# Altium schematic sheet FPGA_IO.SchDoc
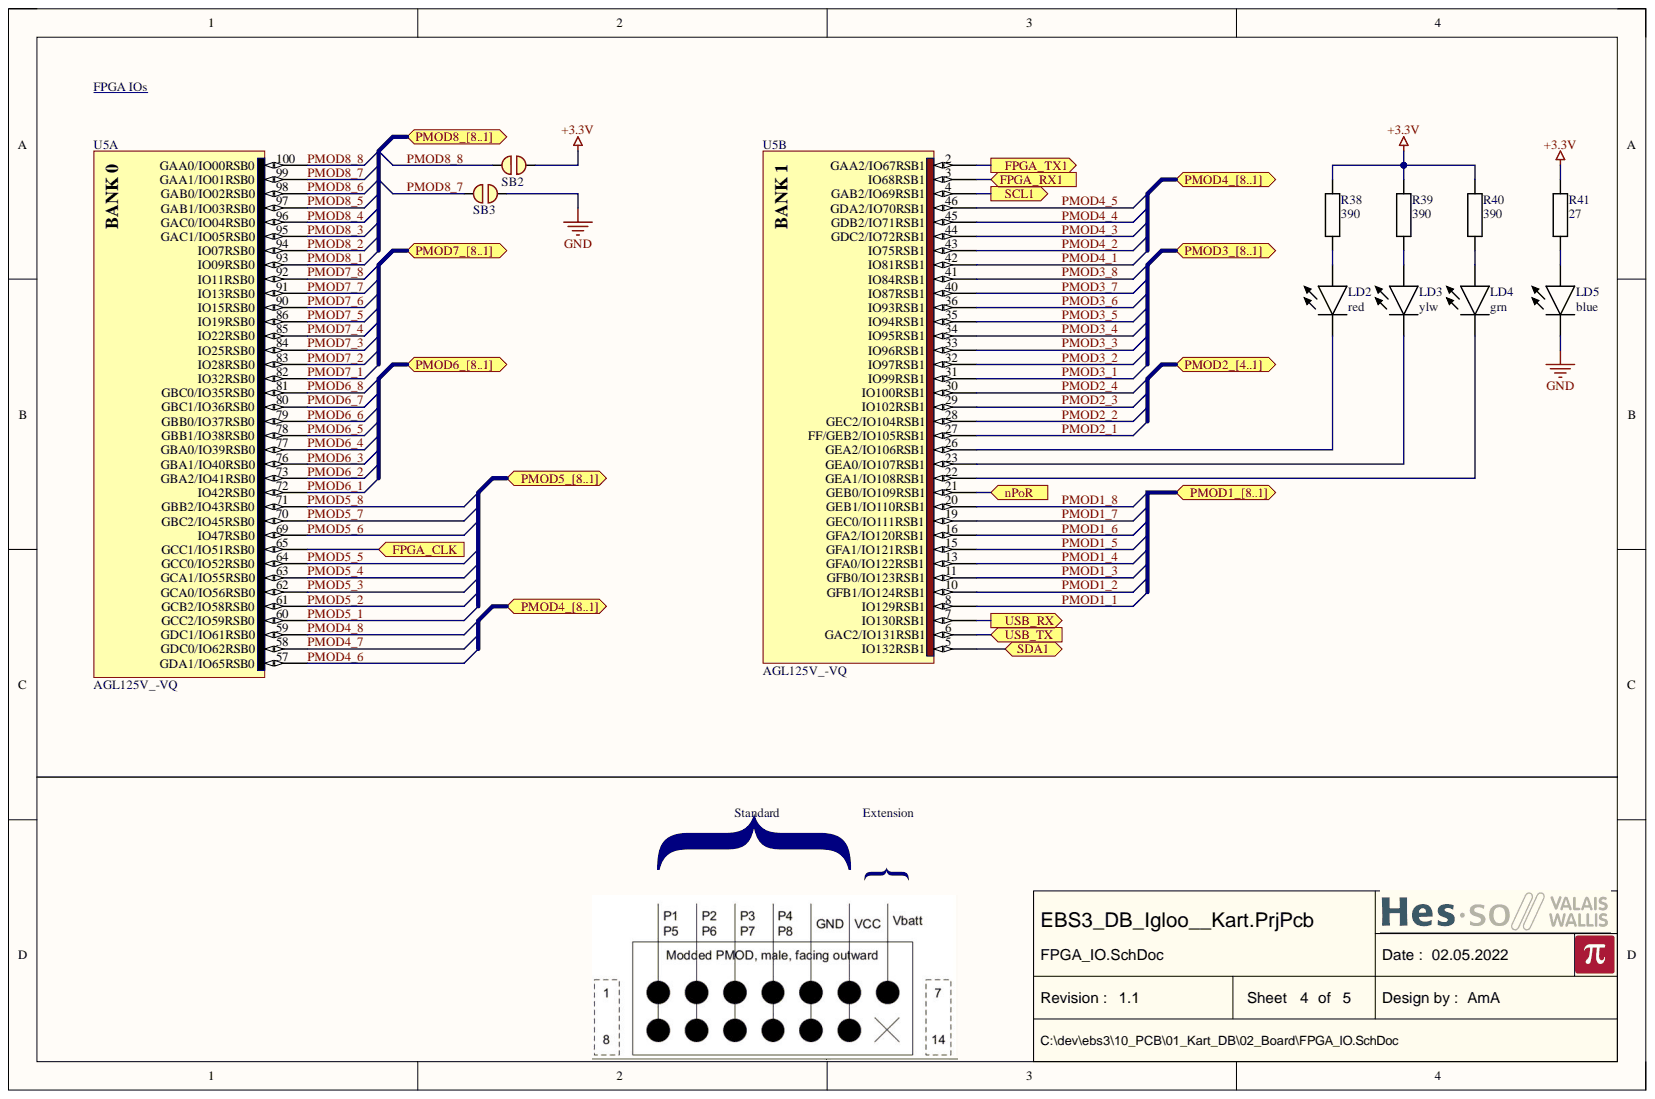
[diagram p0: FPGA_IO.SchDoc]

## Parts
LD2  red  [LED 1608 REFLOW]
LD3  ylw  [LED 1608 REFLOW]
LD4  grn  [LED 1608 REFLOW]
LD5  blue  [LED 1608 REFLOW]
R38  390  [RESISTOR 1608 REFLOW]
R39  390  [RESISTOR 1608 REFLOW]
R40  390  [RESISTOR 1608 REFLOW]
R41  27  [RESISTOR 1608 REFLOW]
SB2  (no value)  [Solder Bridge 1mm Unconnected]
SB3  (no value)  [Solder Bridge 1mm Unconnected]
U5  AGL125V_-VQ  [VQ100 P0.5 C14x14 H1.2]  (parts A,B)

## Ports
FPGA_CLK, FPGA_RX1, FPGA_TX1, nPoR, PMOD1_1, PMOD1_2, PMOD1_3, PMOD1_4, PMOD1_5, PMOD1_6, PMOD1_7, PMOD1_8, PMOD1_[8..1], PMOD2_1, PMOD2_2, PMOD2_3, PMOD2_4, PMOD2_[4..1], PMOD3_1, PMOD3_2, PMOD3_3, PMOD3_4, PMOD3_5, PMOD3_6, PMOD3_7, PMOD3_8, PMOD3_[8..1], PMOD4_1, PMOD4_2, PMOD4_3, PMOD4_4, PMOD4_5, PMOD4_6, PMOD4_7, PMOD4_8, PMOD4_[8..1], PMOD5_1, PMOD5_2, PMOD5_3, PMOD5_4, PMOD5_5, PMOD5_6, PMOD5_7, PMOD5_8, PMOD5_[8..1], PMOD6_1, PMOD6_2, PMOD6_3, PMOD6_4, PMOD6_5, PMOD6_6, PMOD6_7, PMOD6_8, PMOD6_[8..1], PMOD7_1, PMOD7_2, PMOD7_3, PMOD7_4, PMOD7_5, PMOD7_6, PMOD7_7, PMOD7_8, PMOD7_[8..1], PMOD8_1, PMOD8_2, PMOD8_3, PMOD8_4, PMOD8_5, PMOD8_6, PMOD8_7, PMOD8_8, PMOD8_[8..1], SCL1, SDA1, USB_RX, USB_TX

## Nets
+3.3V: R38.2 R39.2 R40.2 R41.2 SB2.2
GND: LD5.1 SB3.2
FPGA_CLK: U5.65(GCC1/IO51RSB0) ; port FPGA_CLK
FPGA_RX1: U5.3(IO68RSB1) ; port FPGA_RX1
FPGA_TX1: U5.2(GAA2/IO67RSB1) ; port FPGA_TX1
nPoR: U5.21(GEB0/IO109RSB1) ; port nPoR
PMOD1_1: U5.8(IO129RSB1) ; port PMOD1_[8..1]  [also labelled ]
PMOD1_2: U5.10(GFB1/IO124RSB1) ; port PMOD1_[8..1]  [also labelled ]
PMOD1_3: U5.11(GFB0/IO123RSB1) ; port PMOD1_[8..1]  [also labelled ]
PMOD1_4: U5.13(GFA0/IO122RSB1) ; port PMOD1_[8..1]  [also labelled ]
PMOD1_5: U5.15(GFA1/IO121RSB1) ; port PMOD1_[8..1]  [also labelled ]
PMOD1_6: U5.16(GFA2/IO120RSB1) ; port PMOD1_[8..1]  [also labelled ]
PMOD1_7: U5.19(GEC0/IO111RSB1) ; port PMOD1_[8..1]  [also labelled ]
PMOD1_8: U5.20(GEB1/IO110RSB1) ; port PMOD1_[8..1]  [also labelled ]
PMOD2_1: U5.27(FF/GEB2/IO105RSB1) ; port PMOD2_[4..1]  [also labelled ]
PMOD2_2: U5.28(GEC2/IO104RSB1) ; port PMOD2_[4..1]  [also labelled ]
PMOD2_3: U5.29(IO102RSB1) ; port PMOD2_[4..1]  [also labelled ]
PMOD2_4: U5.30(IO100RSB1) ; port PMOD2_[4..1]  [also labelled ]
PMOD3_1: U5.31(IO99RSB1) ; port PMOD3_[8..1]  [also labelled ]
PMOD3_2: U5.32(IO97RSB1) ; port PMOD3_[8..1]  [also labelled ]
PMOD3_3: U5.33(IO96RSB1) ; port PMOD3_[8..1]  [also labelled ]
PMOD3_4: U5.34(IO95RSB1) ; port PMOD3_[8..1]  [also labelled ]
PMOD3_5: U5.35(IO94RSB1) ; port PMOD3_[8..1]  [also labelled ]
PMOD3_6: U5.36(IO93RSB1) ; port PMOD3_[8..1]  [also labelled ]
PMOD3_7: U5.40(IO87RSB1) ; port PMOD3_[8..1]  [also labelled ]
PMOD3_8: U5.41(IO84RSB1) ; port PMOD3_[8..1]  [also labelled ]
PMOD4_1: U5.42(IO81RSB1) ; port PMOD4_[8..1]  [also labelled ]
PMOD4_2: U5.43(IO75RSB1) ; port PMOD4_[8..1]  [also labelled ]
PMOD4_3: U5.44(GDC2/IO72RSB1) ; port PMOD4_[8..1]  [also labelled ]
PMOD4_4: U5.45(GDB2/IO71RSB1) ; port PMOD4_[8..1]  [also labelled ]
PMOD4_5: U5.46(GDA2/IO70RSB1) ; port PMOD4_[8..1]  [also labelled ]
PMOD4_6: U5.57(GDA1/IO65RSB0) ; port PMOD4_[8..1]  [also labelled ]
PMOD4_7: U5.58(GDC0/IO62RSB0) ; port PMOD4_[8..1]  [also labelled ]
PMOD4_8: U5.59(GDC1/IO61RSB0) ; port PMOD4_[8..1]  [also labelled ]
PMOD5_1: U5.60(GCC2/IO59RSB0) ; port PMOD5_[8..1]  [also labelled ]
PMOD5_2: U5.61(GCB2/IO58RSB0) ; port PMOD5_[8..1]  [also labelled ]
PMOD5_3: U5.62(GCA0/IO56RSB0) ; port PMOD5_[8..1]  [also labelled ]
PMOD5_4: U5.63(GCA1/IO55RSB0) ; port PMOD5_[8..1]  [also labelled ]
PMOD5_5: U5.64(GCC0/IO52RSB0) ; port PMOD5_[8..1]  [also labelled ]
PMOD5_6: U5.69(IO47RSB0) ; port PMOD5_[8..1]  [also labelled ]
PMOD5_7: U5.70(GBC2/IO45RSB0) ; port PMOD5_[8..1]  [also labelled ]
PMOD5_8: U5.71(GBB2/IO43RSB0) ; port PMOD5_[8..1]  [also labelled ]
PMOD6_1: U5.72(IO42RSB0) ; port PMOD6_[8..1]  [also labelled ]
PMOD6_2: U5.73(GBA2/IO41RSB0) ; port PMOD6_[8..1]  [also labelled ]
PMOD6_3: U5.76(GBA1/IO40RSB0) ; port PMOD6_[8..1]  [also labelled ]
PMOD6_4: U5.77(GBA0/IO39RSB0) ; port PMOD6_[8..1]  [also labelled ]
PMOD6_5: U5.78(GBB1/IO38RSB0) ; port PMOD6_[8..1]  [also labelled ]
PMOD6_6: U5.79(GBB0/IO37RSB0) ; port PMOD6_[8..1]  [also labelled ]
PMOD6_7: U5.80(GBC1/IO36RSB0) ; port PMOD6_[8..1]  [also labelled ]
PMOD6_8: U5.81(GBC0/IO35RSB0) ; port PMOD6_[8..1]  [also labelled ]
PMOD7_1: U5.82(IO32RSB0) ; port PMOD7_[8..1]  [also labelled ]
PMOD7_2: U5.83(IO28RSB0) ; port PMOD7_[8..1]  [also labelled ]
PMOD7_3: U5.84(IO25RSB0) ; port PMOD7_[8..1]  [also labelled ]
PMOD7_4: U5.85(IO22RSB0) ; port PMOD7_[8..1]  [also labelled ]
PMOD7_5: U5.86(IO19RSB0) ; port PMOD7_[8..1]  [also labelled ]
PMOD7_6: U5.90(IO15RSB0) ; port PMOD7_[8..1]  [also labelled ]
PMOD7_7: U5.91(IO13RSB0) ; port PMOD7_[8..1]  [also labelled ]
PMOD7_8: U5.92(IO11RSB0) ; port PMOD7_[8..1]  [also labelled ]
PMOD8_1: U5.93(IO09RSB0) ; port PMOD8_[8..1]  [also labelled ]
PMOD8_2: U5.94(IO07RSB0) ; port PMOD8_[8..1]  [also labelled ]
PMOD8_3: U5.95(GAC1/IO05RSB0) ; port PMOD8_[8..1]  [also labelled ]
PMOD8_4: U5.96(GAC0/IO04RSB0) ; port PMOD8_[8..1]  [also labelled ]
PMOD8_5: U5.97(GAB1/IO03RSB0) ; port PMOD8_[8..1]  [also labelled ]
PMOD8_6: U5.98(GAB0/IO02RSB0) ; port PMOD8_[8..1]  [also labelled ]
PMOD8_7: SB3.1 U5.99(GAA1/IO01RSB0) ; port PMOD8_[8..1]  [also labelled ]
PMOD8_8: SB2.1 U5.100(GAA0/IO00RSB0) ; port PMOD8_[8..1]  [also labelled ]
SCL1: U5.4(GAB2/IO69RSB1) ; port SCL1
SDA1: U5.5(IO132RSB1) ; port SDA1
USB_RX: U5.7(IO130RSB1) ; port USB_RX
USB_TX: U5.6(GAC2/IO131RSB1) ; port USB_TX
NetLD2_1: LD2.1 U5.26(GEA2/IO106RSB1)
NetLD2_2: LD2.2 R38.1
NetLD3_1: LD3.1 U5.23(GEA0/IO107RSB1)
NetLD3_2: LD3.2 R39.1
NetLD4_1: LD4.1 U5.22(GEA1/IO108RSB1)
NetLD4_2: LD4.2 R40.1
NetLD5_2: LD5.2 R41.1

## Library data of the parts
LD2-LD5 = LED: LED generic; Component Kind: Standard; Library Name: Diode.IntLib
  LD2: Value: red
  LD3: Value: ylw
  LD4: Value: grn
  LD5: Value: blue
R38-R41 = Resistor: Component Kind: Standard; Description: Resistor; Library Name: Resistor.IntLib
  R38-R40: Value: 390
  R41: Value: 27
SB2 SB3 = Solderbridge Unconnected: Component Kind: Net Tie; Description: Solderbridge Unconnected; Library Name: Jumper.IntLib
U5 = AGL125V_-VQ: IGLOO Low Power Flash FPGAs; Component Kind: Standard; Library Name: Processor.IntLib; Value: AGL125V_-VQ
